# Altium schematic sheet STM32F429.SchDoc(STM32F429)
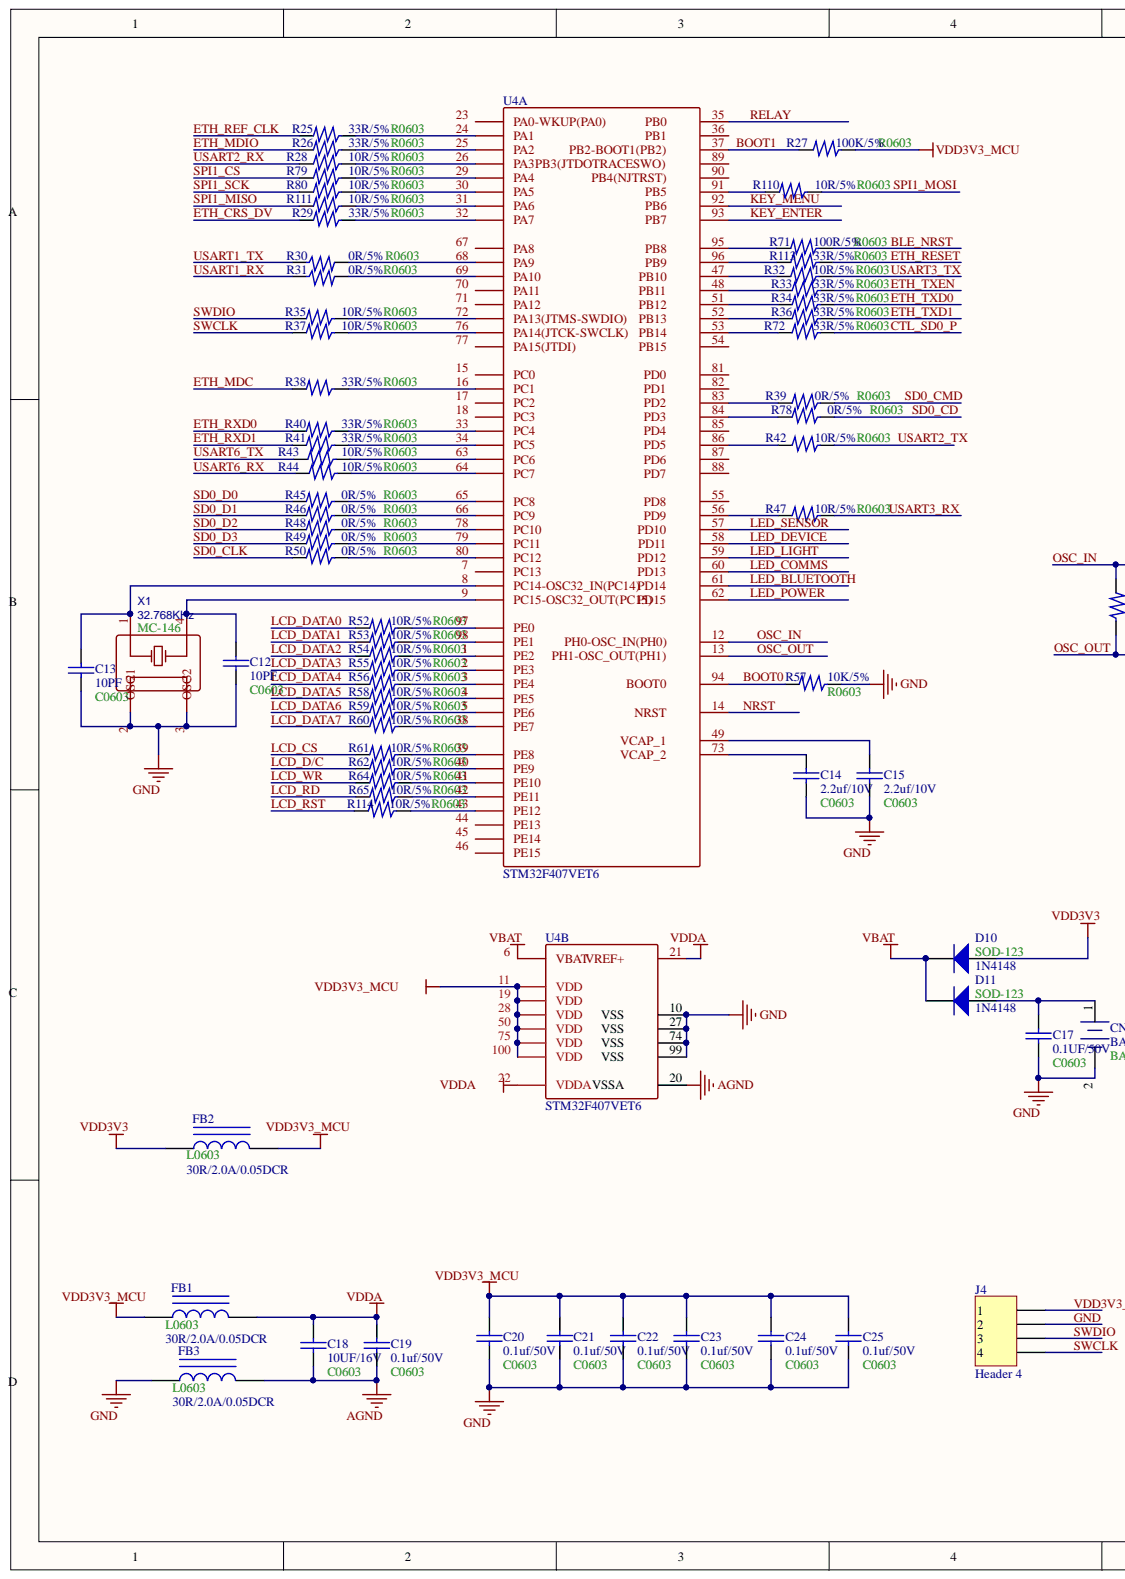
[diagram p0: STM32F429.SchDoc, left]
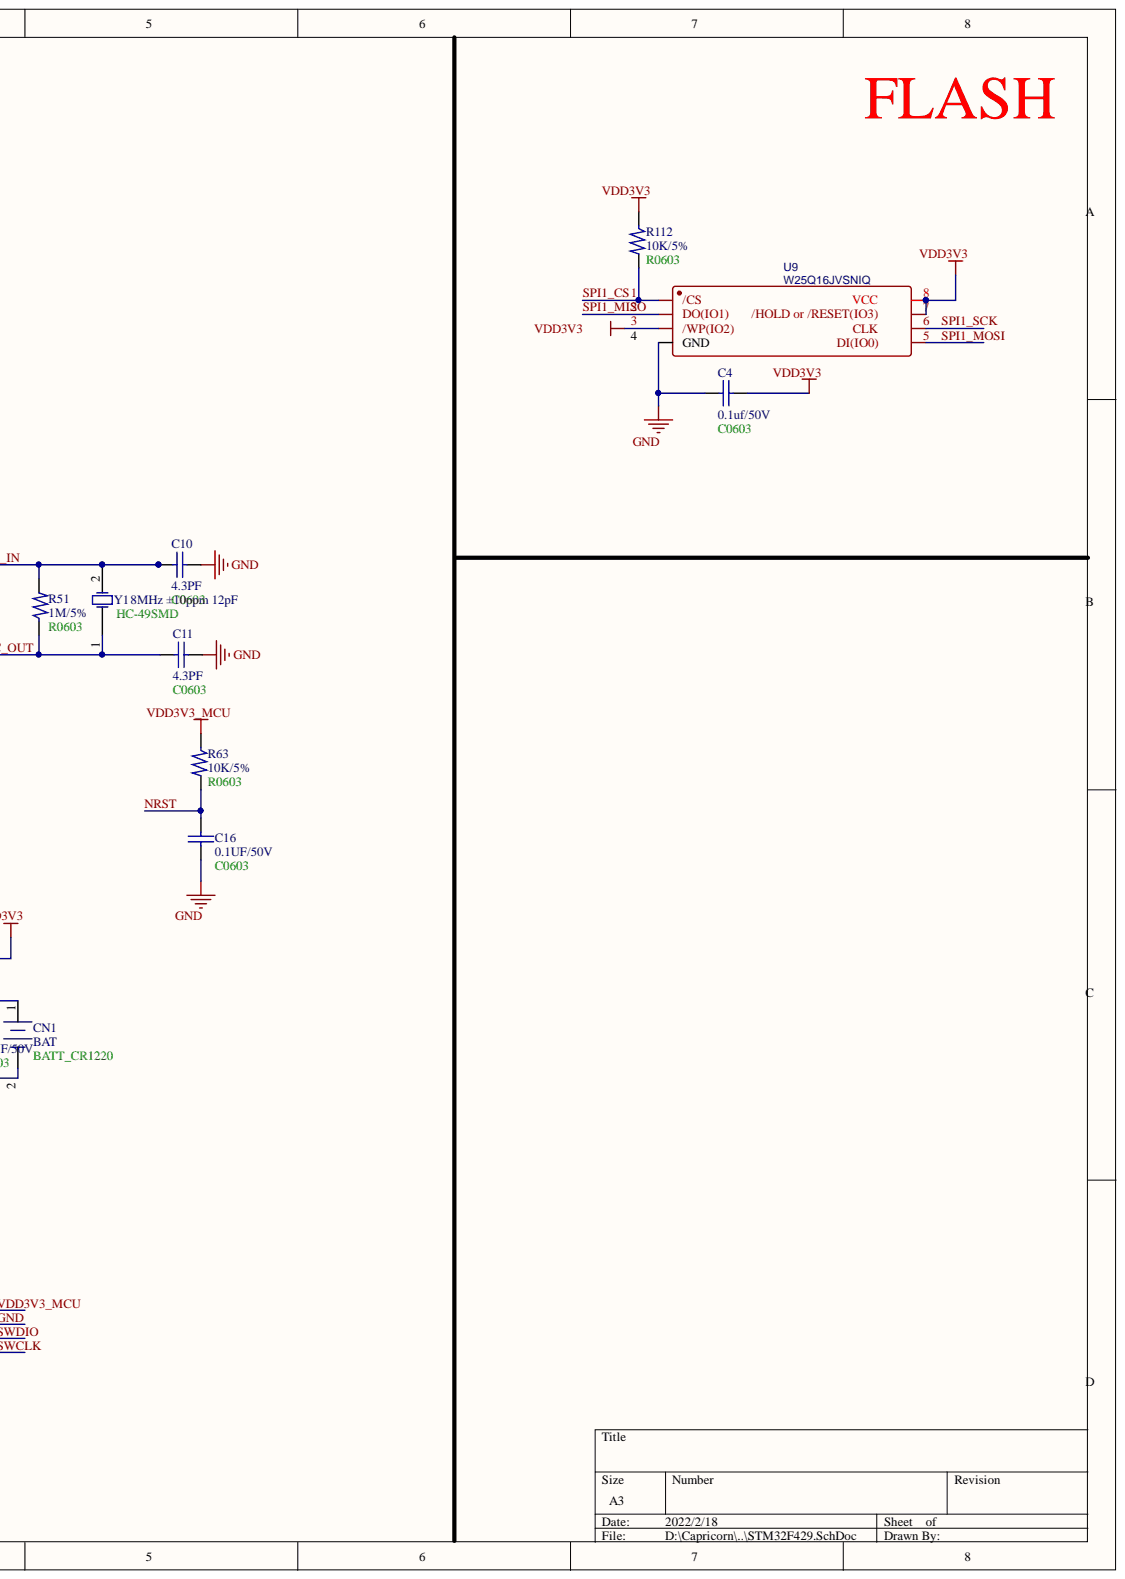
[diagram p1: STM32F429.SchDoc, right]

## Parts
C4  0.1uf/50V  [C0603]
C10  4.3PF  [C0603]
C11  4.3PF  [C0603]
C12  10PF  [C0603]
C13  10PF  [C0603]
C14  2.2uf/10V  [C0603]
C15  2.2uf/10V  [C0603]
C16  0.1UF/50V  [C0603]
C17  0.1UF/50V  [C0603]
C18  10UF/16V  [C0603]
C19  0.1uf/50V  [C0603]
C20  0.1uf/50V  [C0603]
C21  0.1uf/50V  [C0603]
C22  0.1uf/50V  [C0603]
C23  0.1uf/50V  [C0603]
C24  0.1uf/50V  [C0603]
C25  0.1uf/50V  [C0603]
CN1  BAT  [BATT_CR1220]
D10  1N4148  [SOD-123]
D11  1N4148  [SOD-123]
FB1  30R/2.0A/0.05DCR  Package: L0603  [0603_L]
FB2  30R/2.0A/0.05DCR  Package: L0603  [0603_L]
FB3  30R/2.0A/0.05DCR  Package: L0603  [0603_L]
J4  Header 4  [CON4-2.0]
R25  33R/5%  [R0603]
R26  33R/5%  [R0603]
R27  100K/5%  [R0603]
R28  10R/5%  [R0603]
R29  33R/5%  [R0603]
R30  0R/5%  [R0603]
R31  0R/5%  [R0603]
R32  10R/5%  [R0603]
R33  33R/5%  [R0603]
R34  33R/5%  [R0603]
R35  10R/5%  [R0603]
R36  33R/5%  [R0603]
R37  10R/5%  [R0603]
R38  33R/5%  [R0603]
R39  0R/5%  [R0603]
R40  33R/5%  [R0603]
R41  33R/5%  [R0603]
R42  10R/5%  [R0603]
R43  10R/5%  [R0603]
R44  10R/5%  [R0603]
R45  0R/5%  [R0603]
R46  0R/5%  [R0603]
R47  10R/5%  [R0603]
R48  0R/5%  [R0603]
R49  0R/5%  [R0603]
R50  0R/5%  [R0603]
R51  1M/5%  [R0603]
R52  10R/5%  [R0603]
R53  10R/5%  [R0603]
R54  10R/5%  [R0603]
R55  10R/5%  [R0603]
R56  10R/5%  [R0603]
R57  10K/5%  [R0603]
R58  10R/5%  [R0603]
R59  10R/5%  [R0603]
R60  10R/5%  [R0603]
R61  10R/5%  [R0603]
R62  10R/5%  [R0603]
R63  10K/5%  [R0603]
R64  10R/5%  [R0603]
R65  10R/5%  [R0603]
R71  100R/5%  [R0603]
R72  33R/5%  [R0603]
R78  0R/5%  [R0603]
R79  10R/5%  [R0603]
R80  10R/5%  [R0603]
R110  10R/5%  [R0603]
R111  10R/5%  [R0603]
R112  10K/5%  [R0603]
R113  33R/5%  [R0603]
R114  10R/5%  [R0603]
U4  STM32F407VET6  [LQFP-100_L14.0-W14.0-P0.50-LS16.0-BL]  (parts A,B)
U9  W25Q16JVSNIQ  [SOIC-8_208mil]
X1  32.768KHz  [MC-146_4P]
Y1  8MHz ±10ppm 12pF  [HC-49SMD]  (value not drawn)

## Nets
AGND: C18.2 C19.2 FB3.2 U4.20
VBAT: D10.2 D11.2 U4.6(VBAT)
VDD3V3: C4.2 D10.1 FB2.1 R112.2 U9.3(/WP(IO2)) U9.7 U9.8
VDDA: C18.1 C19.1 FB1.2 U4.21 U4.22
BLE_NRST: R71.2
BOOT0: R57.1 U4.94
BOOT1: R27.1 U4.37
CTL_SD0_P: R72.2
ETH_CRS_DV: R29.1
ETH_MDC: R38.1
ETH_MDIO: R26.1
ETH_REF_CLK: R25.1
ETH_RESET: R113.2
ETH_RXD0: R40.1
ETH_RXD1: R41.1
ETH_TXD0: R34.2
ETH_TXD1: R36.2
ETH_TXEN: R33.2
GND: C4.1 C10.2 C11.2 C12.2 C13.2 C14.2 C15.2 C16.1 C17.1 C20.2 C21.2 C22.2 C23.2 C24.2 C25.2 CN1.2 FB3.1 J4.2 R57.2 U4.10 U4.27 U4.74 U4.99 U9.4(GND) X1.2 X1.3
KEY_ENTER: U4.93
KEY_MENU: U4.92
LCD_CS: R61.1
LCD_D/C: R62.1
LCD_DATA0: R52.1
LCD_DATA1: R53.1
LCD_DATA2: R54.1
LCD_DATA3: R55.1
LCD_DATA4: R56.1
LCD_DATA5: R58.1
LCD_DATA6: R59.1
LCD_DATA7: R60.1
LCD_RD: R65.1
LCD_RST: R114.1
LCD_WR: R64.1
LED_BLUETOOTH: U4.61
LED_COMMS: U4.60
LED_DEVICE: U4.58
LED_LIGHT: U4.59
LED_POWER: U4.62
LED_SENSOR: U4.57
NRST: C16.2 R63.1 U4.14
OSC_IN: C10.1 R51.1 U4.12 Y1.2
OSC_OUT: C11.1 R51.2 U4.13 Y1.1
RELAY: U4.35
SD0_CD: R78.2
SD0_CLK: R50.1
SD0_CMD: R39.2
SD0_D0: R45.1
SD0_D1: R46.1
SD0_D2: R48.1
SD0_D3: R49.1
SPI1_CS: R79.1 R112.1 U9.1(/CS)
SPI1_MISO: R111.1 U9.2(DO(IO1))
SPI1_MOSI: R110.2 U9.5
SPI1_SCK: R80.1 U9.6
SWCLK: J4.4 R37.1
SWDIO: J4.3 R35.1
USART1_RX: R31.2
USART1_TX: R30.2
USART2_RX: R28.1
USART2_TX: R42.2
USART3_RX: R47.2
USART3_TX: R32.2
USART6_RX: R44.1
USART6_TX: R43.1
VDD3V3_MCU: C20.1 C21.1 C22.1 C23.1 C24.1 C25.1 FB1.1 FB2.2 J4.1 R27.2 R63.2 U4.11(VDD) U4.19(VDD) U4.28(VDD) U4.50(VDD) U4.75(VDD) U4.100(VDD)
NetC12_1: C12.1 U4.9(PC15-OSC32_OUT(PC15)) X1.4
NetC13_1: C13.1 U4.8(PC14-OSC32_IN(PC14)) X1.1
NetC14_1: C14.1 U4.73
NetC15_1: C15.1 U4.49
NetC17_2: C17.2 CN1.1 D11.1
NetR25_2: R25.2 U4.24(PA1)
NetR26_2: R26.2 U4.25(PA2)
NetR28_2: R28.2 U4.26
NetR29_2: R29.2 U4.32(PA7)
NetR30_1: R30.1 U4.68(PA9)
NetR31_1: R31.1 U4.69(PA10)
NetR32_1: R32.1 U4.47
NetR33_1: R33.1 U4.48
NetR34_1: R34.1 U4.51
NetR35_2: R35.2 U4.72(PA13(JTMS-SWDIO))
NetR36_1: R36.1 U4.52
NetR37_2: R37.2 U4.76(PA14(JTCK-SWCLK))
NetR38_2: R38.2 U4.16(PC1)
NetR39_1: R39.1 U4.83
NetR40_2: R40.2 U4.33(PC4)
NetR41_2: R41.2 U4.34(PC5)
NetR42_1: R42.1 U4.86
NetR43_2: R43.2 U4.63(PC6)
NetR44_2: R44.2 U4.64(PC7)
NetR45_2: R45.2 U4.65(PC8)
NetR46_2: R46.2 U4.66(PC9)
NetR47_1: R47.1 U4.56
NetR48_2: R48.2 U4.78(PC10)
NetR49_2: R49.2 U4.79(PC11)
NetR50_2: R50.2 U4.80(PC12)
NetR52_2: R52.2 U4.97(PE0)
NetR53_2: R53.2 U4.98(PE1)
NetR54_2: R54.2 U4.1(PE2)
NetR55_2: R55.2 U4.2(PE3)
NetR56_2: R56.2 U4.3(PE4)
NetR58_2: R58.2 U4.4(PE5)
NetR59_2: R59.2 U4.5(PE6)
NetR60_2: R60.2 U4.38(PE7)
NetR61_2: R61.2 U4.39(PE8)
NetR62_2: R62.2 U4.40(PE9)
NetR64_2: R64.2 U4.41(PE10)
NetR65_2: R65.2 U4.42(PE11)
NetR71_1: R71.1 U4.95
NetR72_1: R72.1 U4.53
NetR78_1: R78.1 U4.84
NetR79_2: R79.2 U4.29(PA4)
NetR80_2: R80.2 U4.30(PA5)
NetR110_1: R110.1 U4.91
NetR111_2: R111.2 U4.31(PA6)
NetR113_1: R113.1 U4.96
NetR114_2: R114.2 U4.43(PE12)

Not connected: U4 7(PC13) 15(PC0) 17(PC2) 18(PC3) 23(PA0-WKUP(PA0)) 36 44(PE13) 45(PE14) 46(PE15) 54 55 67(PA8) 70(PA11) 71(PA12) 77(PA15(JTDI)) 81 82 85 87 88 89 90

## Library data of the parts
C4 C10-C25 = CAP: Capacitor; Component Kind: Standard
D10 D11 = SOD-123 B5819W  SL: 肖特基二极管; Component Kind: Standard; ComponentLink1Description: 供应商链接; ComponentLink1URL: http://www.szlcsc.com/product/details_9093.html; Library Name: Miscellaneous Devices LC.IntLib; Package: SOD-123; Supplier: LC; SuppliersPartNumber: C8598
FB1-FB3 = 0603 3.9nH 0.3nH: 贴片电感; Component Kind: Standard; ComponentLink1Description: 供应商链接; ComponentLink1URL: http://www.szlcsc.com/product/details_14473.html; Library Name: Miscellaneous Devices LC.IntLib; Supplier: LC; SuppliersPartNumber: C13818
J4 = Header 4: Header, 4-Pin; Component Kind: Standard; LatestRevisionDate: 17-Jul-2002; LatestRevisionNote: Re-released for DXP Platform.; Library Name: Miscellaneous Connectors.IntLib; Signal Integrity: Connector; Publisher: Altium Limited
R25-R65 R71 R72 R78-R80 R110-R114 = 电阻: Component Kind: Standard
U9 = W25Q16JVSNIQ: BOM_Manufacturer: Winbond Elec; Contributor: LCSC; BOM_JLCPCB Part Class: Extended Part; BOM_Manufacturer Part: W25Q16JVSNIQ; BOM_Supplier: LCSC; BOM_Supplier Part: C115407; Component Kind: Standard; spicePre: U; spiceSymbolName: W25Q16JVSNIQ
X1 = 32.768KHz: BOM_Manufacturer: EPSON; BOM_Manufacturer Part: MC-14632.768kHz7.0pF20PPM; Contributor: LCSC; nameAlias: Frequency; SMT Type: Extend; : MC-146; BOM_JLCPCB SMD: Yes; BOM_Supplier: LCSC; BOM_Supplier Part: C94977; Component Kind: Standard; spicePre: X; spiceSymbolName: MC-14632.768kHz7.0pF20PPM
Y1 = SMD-5032_2P 8MHz 20ppm 20pF: 贴片晶振; Component Kind: Standard; ComponentLink1Description: 供应商链接; ComponentLink1URL: http://www.szlcsc.com/product/details_117214.html; Library Name: Miscellaneous Devices LC.IntLib; Package: HC-49SMD; Supplier: LC; SuppliersPartNumber: C115962
CN1 = BAT: Component Kind: Standard; Library Name: M4.SchLib
U4 = STM32F407VET6: Component Kind: Standard; Library Name: M4.SchLib
